# Altium schematic sheet input_output.SchDoc(input_output)
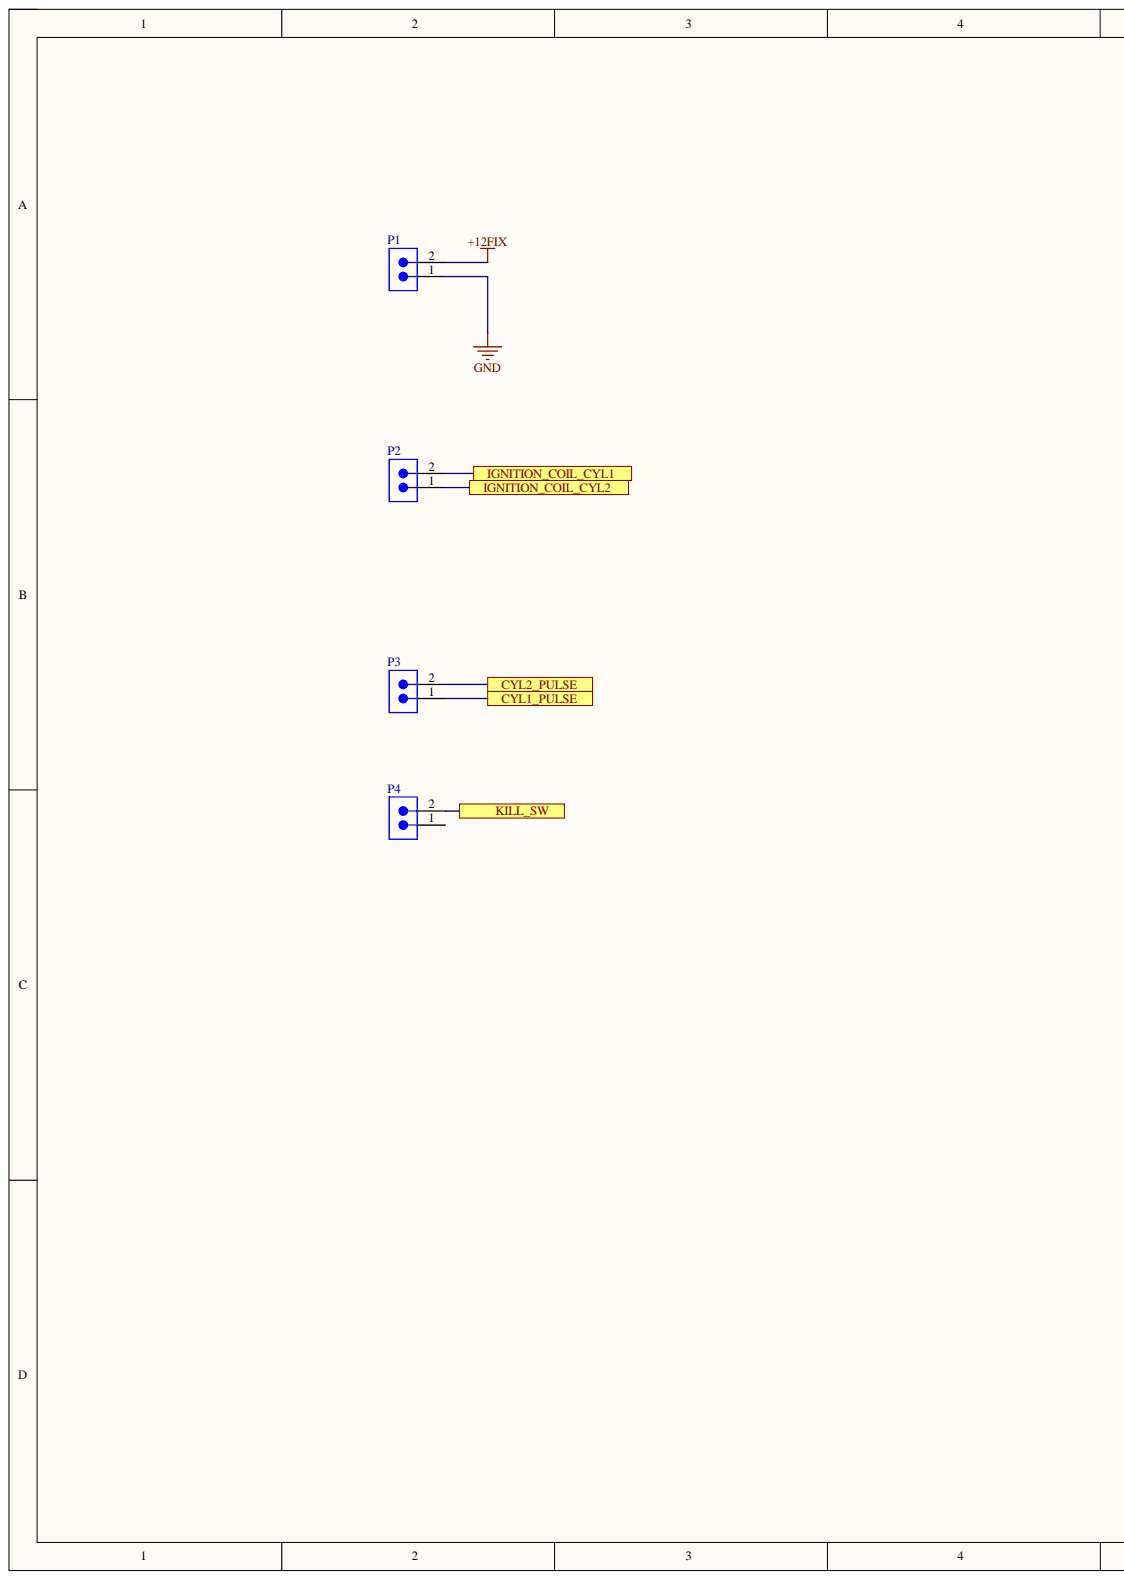
[diagram p0: input_output.SchDoc, left]
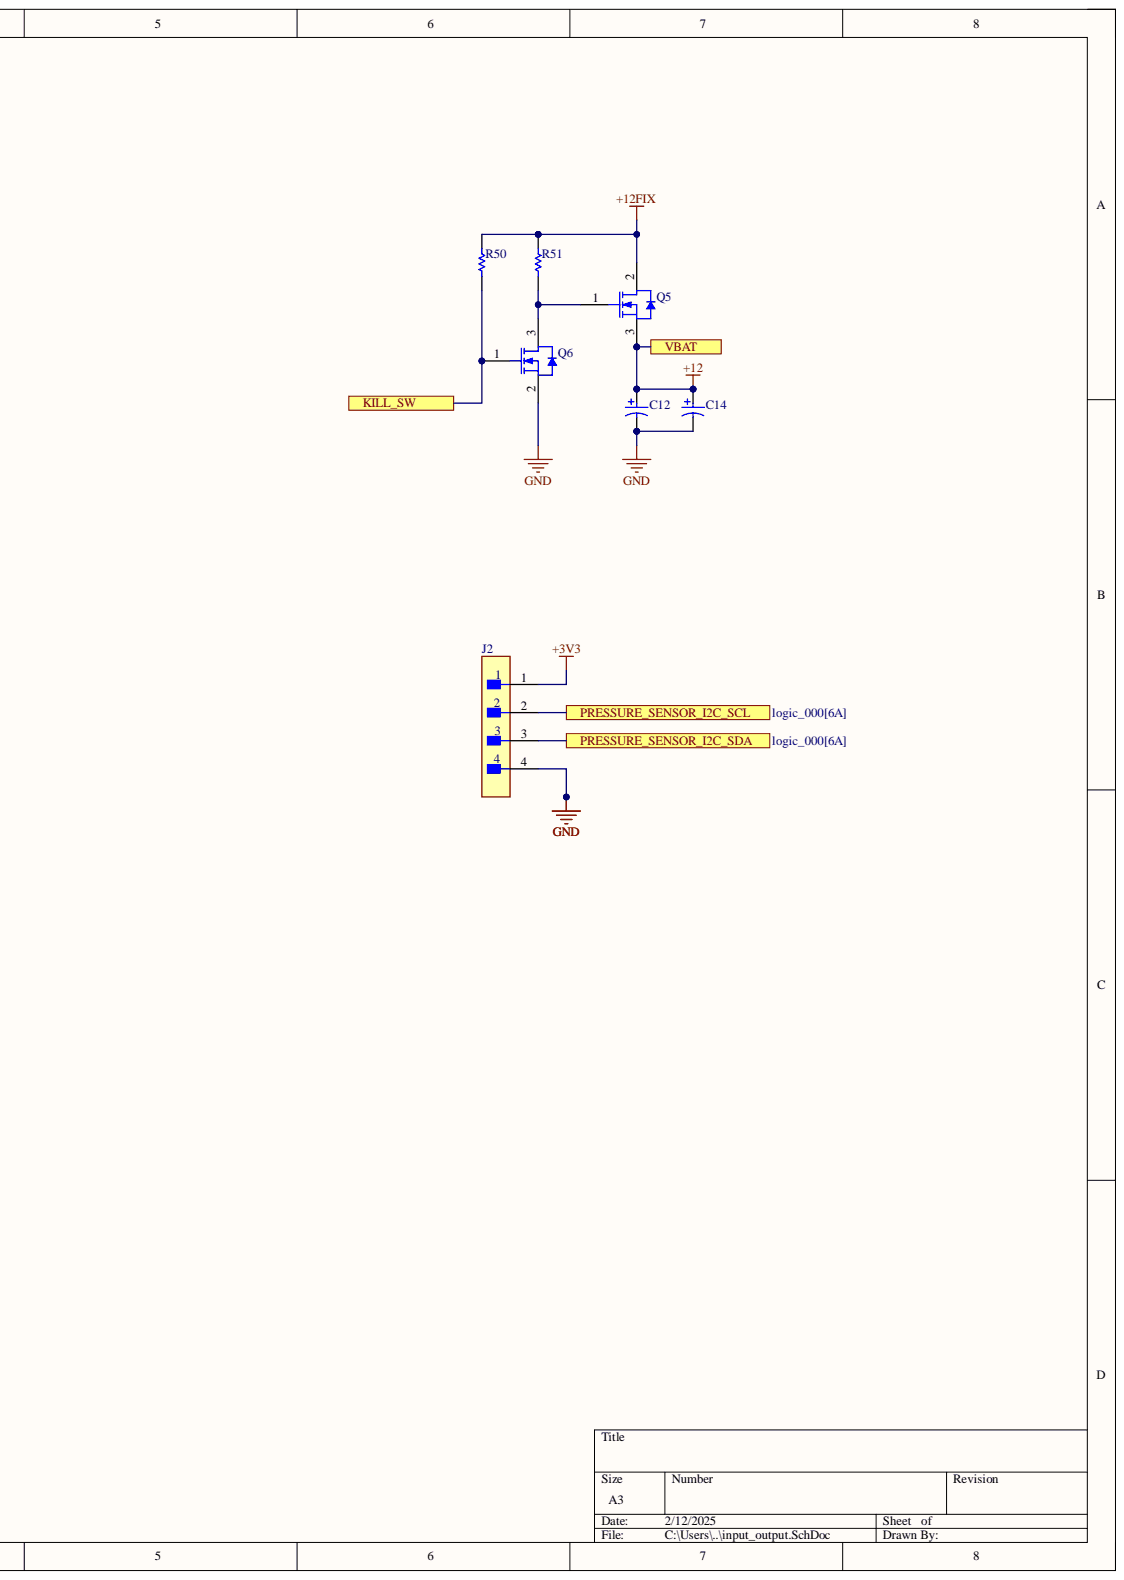
[diagram p1: input_output.SchDoc, right]

## Parts
C12  UUD1A102MNL1GS  [NIC-CAPA2-10X10_V]  (value not drawn)
C14  UUD1A102MNL1GS  [NIC-CAPA2-10X10_V]  (value not drawn)
J2  53047-0410  [FP-53047-0410-MFG]  (value not drawn)
P1  691701510002B  [691701510002B]  (value not drawn)
P2  691701510002B  [691701510002B]  (value not drawn)
P3  691701510002B  [691701510002B]  (value not drawn)
P4  691701510002B  [691701510002B]  (value not drawn)
Q5  IPD600N25N3  [INF-PG-TO252-3-11_A_L]  (value not drawn)
Q6  PMV56XN,215  [NXP-SOT23_L]  (value not drawn)
R50  RC0603FR-07470KL  [RESC1608X55X25ML10T15]  (value not drawn)
R51  RC0603FR-07470KL  [RESC1608X55X25ML10T15]  (value not drawn)

## Ports
CYL1_PULSE, CYL2_PULSE, IGNITION_COIL_CYL1, IGNITION_COIL_CYL2, KILL_SW, PRESSURE_SENSOR_I2C_SCL, PRESSURE_SENSOR_I2C_SDA, VBAT

## Nets
+3V3: J2.1
+12FIX: P1.2 Q5.2 R50.2 R51.2
GND: C12.2 C14.2 J2.4 P1.1 Q6.2
+12: C12.1 C14.1 Q5.3 ; port VBAT
CYL1_PULSE: P3.1 ; port CYL1_PULSE
CYL2_PULSE: P3.2 ; port CYL2_PULSE
IGNITION_COIL_CYL1: P2.2 ; port IGNITION_COIL_CYL1
IGNITION_COIL_CYL2: P2.1 ; port IGNITION_COIL_CYL2
KILL_SW: P4.2 Q6.1 R50.1 ; port KILL_SW
PRESSURE_SENSOR_I2C_SCL: J2.2 ; port PRESSURE_SENSOR_I2C_SCL
PRESSURE_SENSOR_I2C_SDA: J2.3 ; port PRESSURE_SENSOR_I2C_SDA
NetQ5_1: Q5.1 Q6.3 R51.1

Not connected: P4 1

## Library data of the parts
C12 C14 = CMP-0687-00006-1: Aluminum Electrolytic Capacitor, UD Series, Low Impedance, 1000 uF, 10 V, + / - 20%, -55 to 105 degC, Chip Type, 10 x 10 mm D x L, Pb-Free, Reel; Manufacturer: Nichicon; Endurance Time: 5000 h; Impedance: 0.09 Ohm; Packing: Dia382mm Reel; Rated Capacitance: 1000 uF; Rated Ripple Current (at 100kHz): 670 mA; Rated Voltage: 10 V; Tolerance Rated Capacitance: -20 to 20%; Component Kind: Standard; ComponentLink1Description: Manufacturer URL; ComponentLink1URL: http://www.nichicon.co.jp/; ComponentLink2Description: Datasheet; ComponentLink2URL: http://products.nichicon.co.jp/en/pdf/XJA043/e-ud.pdf; ComponentLink3Description: Package Specification; ComponentLink3URL: http://products.nichicon.co.jp/en/pdf/XJA043/e-ud.pdf; DatasheetVersion: 08/2012; Diameter: 10 mm; Length: 10 mm; Library Name: Altium Content Vault; Mounting Technology: Surface Mount; PackageDescription: 2-Pin Surface Mount Device, Diameter 10 mm, Height 10 mm, Pitch 8 mm; PackageReference: CAPAE-D10H10; PartNumber: UUD1A102MNL1GS; RoHS: TRUE
J2 = CMP-04776-000321-1: CONN HEADER VERT 4POS 1.25MM; Contact Resistance: 20mR; Current: 1A; Gender: Male; Glow Wire Compliant: Non-compliant; Insulation Resistance: 100MR; Max Voltage Rating (AC): 125V; Number of Circuits: 4; Plating: Tin; Case/Package: 0410; Color: Natural; Component Kind: Standard; Contact Material: Bronze; Contact Plating: Tin; Current Rating: 1A; Flammability Rating: UL94 V-0; Housing Color: Natural; Housing Material: Polyamide; Lead Free: Lead Free; Library Name: Altium Content Vault; Max Operating Temperature: 85°C; Min Operating Temperature: -40°C; Mount: Through Hole; Number of Contacts: 4; Number of Positions: 4; Number of Rows: 1; Orientation: Vertical; Package Quantity: 5000; Packaging: Tray; Pitch: 1.25mm; Radiation Hardening: No; RoHS Compliant: Yes; Series: PicoBlade; Termination: Solder; Voltage: 125V; Voltage Rating: 125V; Voltage Rating (AC): 125V; Voltage Rating (DC): 125V; Working Voltage: 125V
P1-P4 = CMP-1502-02329-2: NexarPartId: 22866752; Connector Type: Terminal Block; Current Rating: 10A; Gender: Male; Number of Contacts: 2; Pitch: 5.08mm; Voltage Rating: 300V; Color: Black; Component Kind: Standard; Contact Gender: Male; Contact Material: Brass; Contact Plating: Tin; Housing Material: Polymer; Lead Pitch: 5.08mm; Length: 12.96mm; Library Name: Altium Content Vault; Max Operating Temperature: 105°C; Min Operating Temperature: -40°C; Mount: Through Hole; Number of Pins: 2; REACH SVHC: Unknown; RoHS: Compliant; Termination: PCB
Q5 = CMP-1091-00172-1: N-Channel OptiMOS 3 Power-Transistor, 60 V VDS, 90 A ID, -55 to 175 degC, PG-TO252-3-11, Reel, Green; Manufacturer: Infineon; PartNumber: IPD035N06L3 G; Green: TRUE; ID (max): 90Â A; IDpuls (max): 360Â A; Polarity: N; Ptot (max): 167Â W; QG: 59Â nC; RDS (on) (max): 3.5Â mOhm; RDS (on) (max) (@4.5V): 5.5Â mOhm; Rth: 0.9Â K/W; RthJA (max): 62 K/W; VDS (max): 60Â V; VGS(th) (max): 2.2Â V; VGS(th) (min): 1.2Â V; Component Kind: Standard; ComponentLink1Description: Manufacturer URL; ComponentLink1URL: http://www.infineon.com/; ComponentLink2Description: Datasheet; ComponentLink2URL: http://www.infineon.com/dgdl/IPD035N06L3_Rev2.3.pdf?folderId=db3a304313b8b5a60113cee8763b02d7&fileId=db3a30431b3e89eb011b4558ed7f7d14; ComponentLink3Description: Package Specification; ComponentLink3URL: http://www.infineon.com/dgdl/IPD160N04L_rev1.0.pdf?folderId=db3a3043163797a6011643468e7505a4&fileId=db3a3043163797a60116436401cf05cf; DatasheetVersion: Rev. 2.3; Halogen-free: TRUE; Library Name: Altium Content Vault; Mounting Technology: Surface Mount; PackageDescription: 3-Pin Plastic (Green) Transistor Single Outline Package, 6.57 x 6.1 mm Body, 2.29 mm Pitch; PackageReference: PG-TO252-3-11; PackageVersion: Rev. 02, 03/2007; Packing: Reel; RthJC (max): 0.9Â K/W
Q6 = CMP-1258-00163-1: N-Channel uTrenchMOS(TM) Extremely Low Level FET, 20 V, 3.76 A, 3-Pin SOT23, Tape and Reel; Manufacturer: NXP Semiconductors; Channel Type: N; Ciss [typ] (pF): 230; Coss [typ] (pF): 125; ID [max] (A): 3.76; Packing: Tape & Reel; VDS [max] (V): 20; Code_JEDEC: TO-236AB; Component Kind: Standard; ComponentLink1Description: Manufacturer URL; ComponentLink1URL: http://www.nxp.com/; ComponentLink2Description: Datasheet; ComponentLink2URL: http://www.nxp.com/documents/data_sheet/PMV56XN.pdf; ComponentLink3Description: Package Specification; ComponentLink3URL: http://www.nxp.com/documents/outline_drawing/sot023_po.pdf; DatasheetVersion: Rev. 02; Library Name: Altium Content Vault; Mounting Technology: Surface Mount; PackageDescription: 3-Pin Small Outline Transistor Package, Body 2.9 x 1.3 mm, Pitch 0.95 mm; PackageReference: SOT23; PackageVersion: 06-03-16, 03/2006; PartNumber: PMV56XN,215
R50 R51 = CMP-1659-00049-1: Chip Resistor, 470 KOhm, +/- 1%, 0.1 W, -55 to 155 degC, 0603 (1608 Metric), RoHS, Tape and Reel; Component Kind: Standard; ComponentLink1Description: Manufacturer URL; ComponentLink1URL: http://www.yageo.com/; ComponentLink2Description: Datasheet; ComponentLink2URL: http://www.yageo.com/documents/recent/PYu-RC_Group_51_RoHS_L_04.pdf; DatasheetVersion: Rev. 4, 02/2016; Library Name: Altium Content Vault; PackageDescription: 2-Pin Surface Mount Device, Body 1.6 x 0.8 mm; PackageReference: 0603
